# Altium schematic sheet 9040_fm.SchDoc
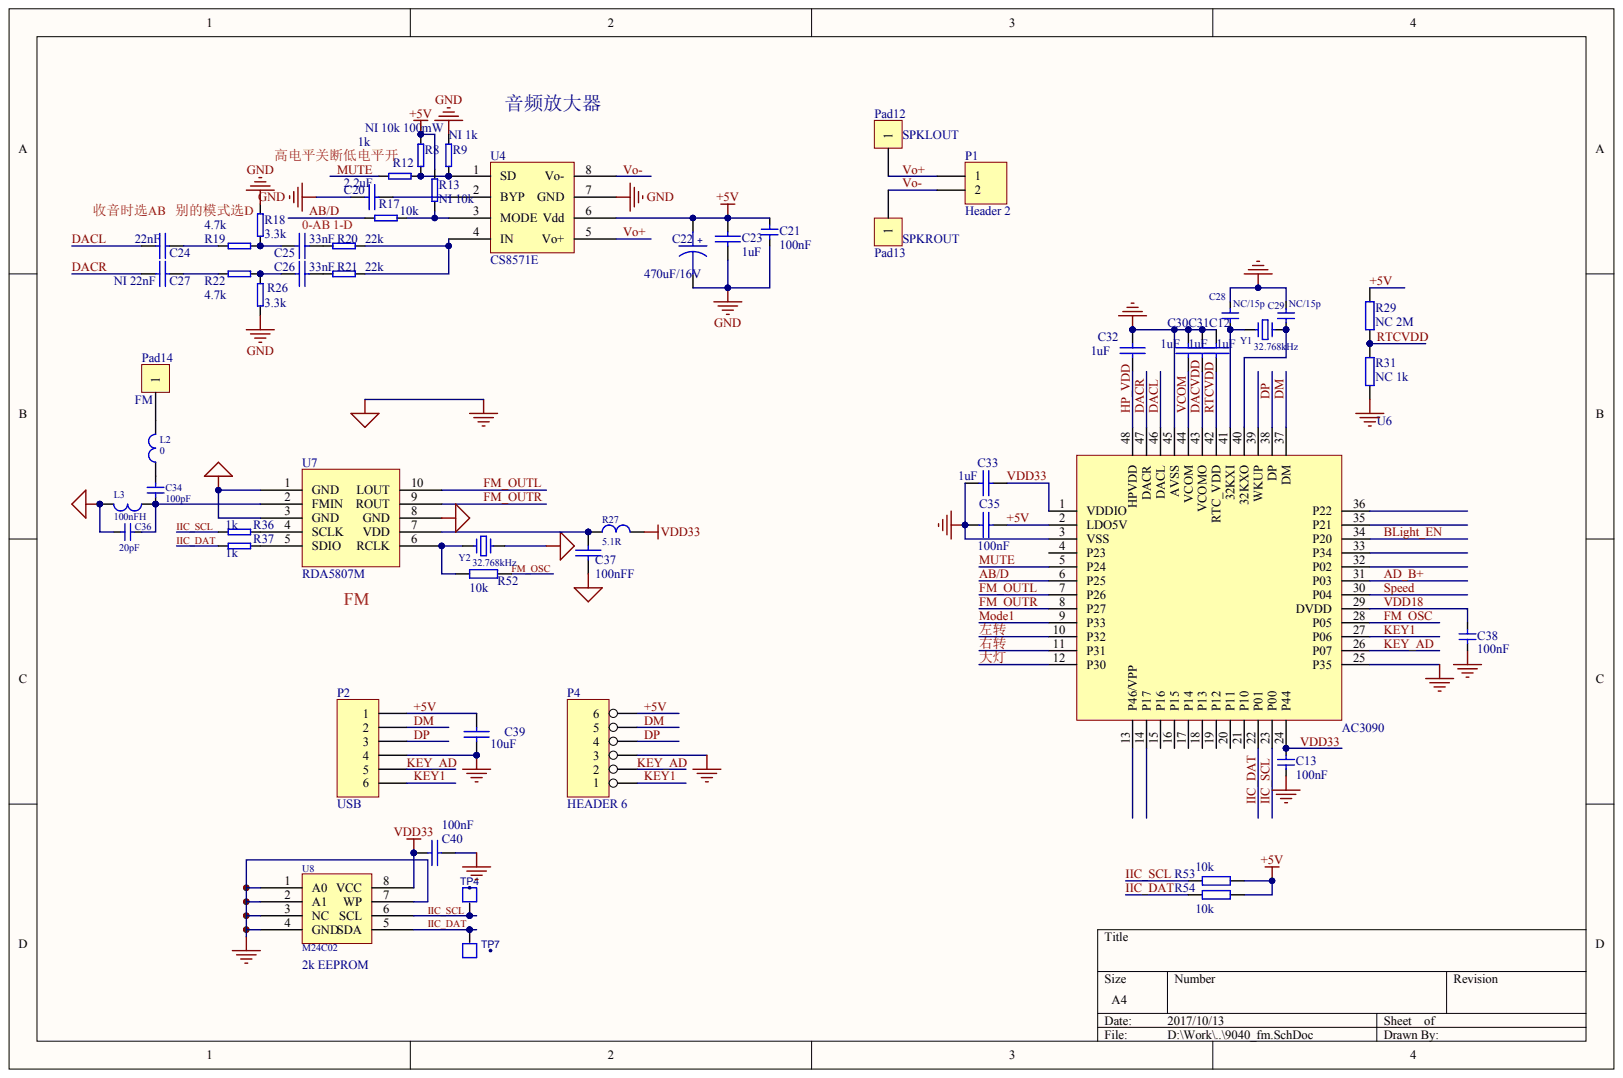
[diagram p0: 9040_fm.SchDoc]

## Parts
C12  1uF
C13  100nF
C20  2.2uF
C21  100nF
C22  470uF/16V
C23  1uF
C24  22nF
C25  33nF
C26  33nF
C27  NI 22nF
C28  NC/15p
C29  NC/15p
C30  1uF
C31  1uF
C32  1uF
C33  1uF
C34  100pF
C35  100nF
C36  20pF
C37  100nFF
C38  100nF
C39  10uF
C40  100nF
L2  0
L3  100nFH
P1  Header 2
P2  USB
P4  HEADER 6
Pad12  SPKROUT  (value not drawn)
Pad13  SPKLOUT  (value not drawn)
Pad14  FM
R8  NI 10k 100mW
R9  NI 1k
R12  1k
R13  NI 10k
R17  10k
R18  3.3k
R19  4.7k
R20  22k
R21  22k
R22  4.7k
R26  3.3k
R27  5.1R
R29  NC 2M
R31  NC 1k
R36  1k
R37  1k
R52  10k
R53  10k
R54  10k
TP4  TP50MIL  (value not drawn)
TP7  TP50MIL  (value not drawn)
U4  CS8571E
U6  AC3090
U7  RDA5807M
U8  M24C02
Y1  32.768kHz
Y2  32.768kHz

## Nets
AGND: C12.1 C13.2 C20.2 C21.2 C22.2 C23.1 C28.2 C29.2 C30.1 C31.1 C32.1 C33.2 C35.1 C36.1 C37.2 C38.2 C39.2 C40.2 L3.2 P2.4 P4.3 R9.2 R18.2 R26.1 R31.2 U4.7(GND) U6.3(VSS) U6.25(P35) U6.45(AVSS) U7.1(GND) U7.3(GND) U7.8(GND) U8.1(A0) U8.2(A1) U8.3(NC) U8.4(GND) U8.7(WP) Y2.1
+5V: C21.1 C22.1 C23.2 C35.2 C39.1 P2.1 P4.6 R8.2 R13.2 R29.1 R53.1 R54.1 U4.6(Vdd) U6.2(LDO5V)
AB/D: R17.1 U6.6(P25)
AD_B+: U6.31(P03)
BLIGHT_EN: U6.34(P20)
DACL: C24.2 U6.46(DACL)
DACR: C27.2 U6.47(DACR)
DACVDD: C31.2 U6.43(VCOMO)
DM: P2.2 P4.5 U6.37(DM)
DP: P2.3 P4.4 U6.38(DP)
FM_OSC: R52.1 U6.28(P05)
FM_OUTL: U6.7(P26) U7.10(LOUT)
FM_OUTR: U6.8(P27) U7.9(ROUT)
HP_VDD: C32.2 U6.48(HPVDD)
IIC_DAT: R37.1 R54.2 TP7.1 U6.22(P01) U8.5(SDA)
IIC_SCL: R36.1 R53.2 TP4.1 U6.23(P00) U8.6(SCL)
KEY1: P2.6 P4.1 U6.27(P06)
KEY_AD: P2.5 P4.2 U6.26(P07)
MODE1: U6.9(P33)
MUTE: R12.1 U6.5(P24)
RTCVDD: C12.2 R29.2 R31.1 U6.42(RTC_VDD)
SPEED: U6.30(P04)
VCOM: C30.2 U6.44(VCOM)
VDD18: C38.1 U6.29(DVDD)
VDD33: C13.1 C33.1 C40.1 R27.2 U6.1(VDDIO) U6.24(P44) U8.8(VCC)
VO+: P1.1 Pad12.1 U4.5(Vo+)
VO-: P1.2 Pad13.1 U4.8(Vo-)
右转: U6.11(P31)
大灯: U6.12(P30)
左转: U6.10(P32)
NetC20_1: C20.1 U4.2(BYP)
NetC24_1: C24.1 R19.1
NetC25_1: C25.1 R20.2
NetC25_2: C25.2 R18.1 R19.2
NetC26_1: C26.1 R21.2
NetC26_2: C26.2 R22.2 R26.2
NetC27_1: C27.1 R22.1
NetC28_1: C28.1 U6.41(32KXI) Y1.2
NetC29_1: C29.1 U6.40(32KXO) Y1.1
NetC34_1: C34.1 C36.2 L3.1 U7.2(FMIN)
NetC34_2: C34.2 L2.1
NetC37_1: C37.1 R27.1 U7.7(VDD)
NetL2_2: L2.2 Pad14.1
NetR8_1: R8.1 R9.1 R12.2 U4.1(SD)
NetR13_1: R13.1 R17.2 U4.3(MODE)
NetR20_1: R20.1 R21.1 U4.4(IN)
NetR36_2: R36.2 U7.4(SCLK)
NetR37_2: R37.2 U7.5(SDIO)
NetR52_2: R52.2 U7.6(RCLK) Y2.2

Not connected: U6 4(P23) 13(P46/VPP) 14(P17) 15(P16) 16(P15) 17(P14) 18(P13) 19(P12) 20(P11) 21(P10) 32(P02) 33(P34) 35(P21) 36(P22) 39(WKUP)

## Library data of the parts
TP4 TP7 = (no symbol name): 中文名称: 工程测试点; Geometry.Height: 0.1mm; Value: TEST POINT; 物料描述: 工程测试点; PCB DECAL: TP50MIL
U8 = (no symbol name): brand: ST
C12 C13 C20-C40 L2 L3 P1 P2 P4 Pad12-Pad14 R8 R9 R12 R13 R17-R22 R26 R27 R29 R31 R36 R37 R52-R54 U4 U6 U7 Y1 Y2 = (no symbol name)
  C34 C36: : 贴片电容
  L2 L3 R27: : 贴片电感
  P1 P2: LatestRevisionDate: 17-Jul-2002; LatestRevisionNote: Re-released for DXP Platform.; Publisher: Altium Limited
